# Altium schematic sheet Sensors.SchDoc(Sensors)
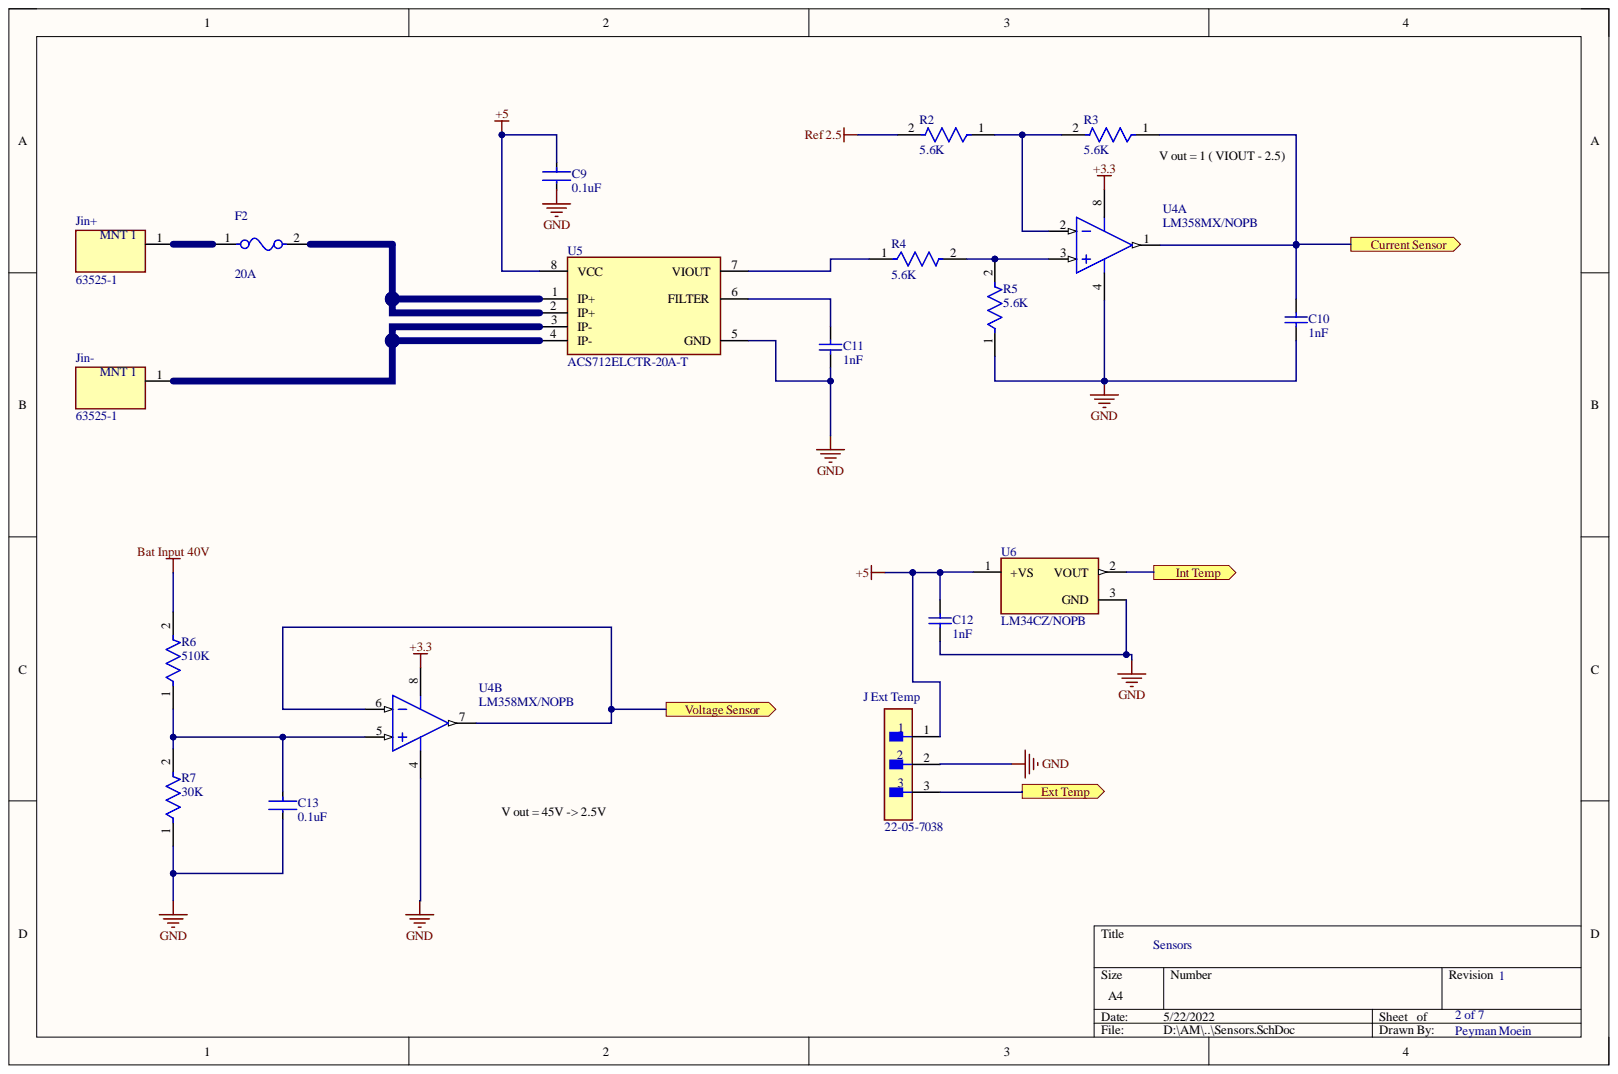
[diagram p0: Sensors.SchDoc]

## Parts
C9  0.1uF  [FP-C0805C-DN-MFG]
C10  1nF  [CAPC2013X70X45NL10T25]
C11  1nF  [CAPC2013X70X45NL10T25]
C12  1nF  [CAPC2013X70X45NL10T25]
C13  0.1uF  [FP-C0805C-DN-MFG]
F2  20A  [FP-0456-MFG]
J_Ext_Temp  22-05-7038  [FP-22-05-7038-MFG]
Jin+  63525-1  [FP-63525-1-MFG]
Jin-  63525-1  [FP-63525-1-MFG]
R2  5.6K  [FP-CRCW0805-e3-MFG]
R3  5.6K  [FP-CRCW0805-e3-MFG]
R4  5.6K  [FP-CRCW0805-e3-MFG]
R5  5.6K  [FP-CRCW0805-e3-MFG]
R6  510K  [FP-CRCW0805-e3-MFG]
R7  30K  [FP-CRCW0805-e3-MFG]
U4  LM358MX/NOPB  [D0008A_L]  (parts A,B)
U5  ACS712ELCTR-20A-T  [FP-LC-8-IPC_C]
U6  LM34CZ/NOPB  [FP-LP0003A-MFG]

## Ports
Current Sensor, Ext Temp, Int Temp, Voltage Sensor

## Nets
+3.3: U4.8
+5: C9.1 C12.2 J_Ext_Temp.1 U5.8(VCC) U6.1
Bat Input 40V: R6.2
GND: C9.2 C10.1 C11.1 C12.1 C13.2 J_Ext_Temp.2 R5.1 R7.1 U4.4 U5.5(GND) U6.3
Ref 2.5: R2.2
Current Sensor: C10.2 R3.1 U4.1 ; port Current Sensor
Ext Temp: J_Ext_Temp.3 ; port Ext Temp
Int Temp: U6.2 ; port Int Temp
Voltage Sensor: U4.6 U4.7 ; port Voltage Sensor
NetC11_2: C11.2 U5.6(FILTER)
NetC13_1: C13.1 R6.1 R7.2 U4.5
NetF2_1: F2.1 Jin+.1
NetF2_2: F2.2 U5.1(IP+) U5.2(IP+)
NetJin-_1: Jin-.1 U5.3(IP-) U5.4(IP-)
NetR2_1: R2.1 R3.2 U4.2
NetR4_1: R4.1 U5.7(VIOUT)
NetR4_2: R4.2 R5.2 U4.3

## Library data of the parts
C9 C13 = CMP-2007-00279-2: CAP CER 0.1UF 50V X7R 0805; Manufacturer: KEMET; Manufacturer Part Number: C0805C104K5RACTU; Capacitance: 0.10 μF; Material: Ceramic; Temperature Coefficient: 30ppm/°C; Tolerance: 0.1; Value: 0.10 μF; Automotive: No; Category: Cap; Component Kind: Standard; ComponentLink2Description: Datasheet; ComponentLink2URL: https://content.kemet.com/datasheets/KEM_C1003_C0G_SMD.pdf; Device Class L1: Passive Components; Device Class L2: Capacitors; Device Class L3: Ceramic Capacitors; Digikey Description: CAP CER 0.1UF 50V X7R 0805; Height: 0.88mm; Ipc Land Pattern Name: CAPC200125X78; Lead Free: Yes; Library Name: Altium Content Vault; Mouser Description: Cap Ceramic 0.1uF 50V X7R 10% SMD 2.0 x 1.2 mm 125 C Paper T/R; Octopart: https://octopart.com/c0805c104k5ractu-kemet-143926; Package: C0805C-DN; RoHS: TRUE; Temperature: -55°C to +125°C; Temperature Characteristic: X7R; Temperature Range High: +125°C; Temperature Range Low: -55°C; Voltage Rating: 50 V
C10-C12 = CMP-2007-00866-1: Manufacturer: Murata; Part Number: GRM2165C1H102JA01D; Component Kind: Standard; Library Name: Altium Content Vault
F2 = CMP-08605-000068-1: FUSE BOARD MNT 20A 125VAC 100VDC; Manufacturer: Littelfuse Inc; Manufacturer Part Number: 0456020.ER; Fuse Blow Speed: Fast; Fuse I2T: 18A2Sec; Fuse Interrupt Ac Current: 300A; Fuse Interrupt Dc Current: 1000A; Fuse Voltage Drop: 64.7mV; Max Fuse Ac Voltage: 125V; Max Fuse Dc Voltage: 100V; Resistance: 2.3mΩ; Value: 2.3mΩ; Automotive: No; Category: Fuse; Component Kind: Standard; ComponentLink2Description: Datasheet; ComponentLink2URL: https://www.littelfuse.com/~/media/electronics/datasheets/fuses/littelfuse_fuse_456_datasheet.pdf.pdf; Device Class L1: Circuit Protection; Device Class L2: Fuses; Device Class L3: unset; Digikey Description: FUSE BOARD MNT 20A 125VAC 100VDC; Fuse Current: 20A; Height: 3.05mm; Lead Free: Yes; Library Name: Altium Content Vault; Mouser Description: Surface Mount Fuses 125V 20A V/FA NANO2; Octopart: https://octopart.com/0456020.er-littelfuse-7502596; Package: 0456; RoHS: TRUE; Temperature: -55°C to +125°C; Temperature Range High: +125°C; Temperature Range Low: -55°C; Voltage Rating: 125V
J_Ext_Temp = CMP-04776-000076-1: CONN HEADER R/A 3POS 2.54MM; Manufacturer: Molex; Conn Gender: Male; Conn Orientation: Right Angle; Current Rating: 4A; Number Of Contacts: 3; Voltage Rating: 500V; Automotive: No; Category: Conn; Component Kind: Standard; ComponentLink2Description: Datasheet; ComponentLink2URL: https://www.molex.com/webdocs/datasheets/pdf/en-us/0022057038_PCB_HEADERS.pdf; Contact Material: Tin,Nickel; Device Class L1: Connectors; Device Class L2: Headers and Wire Housings; Device Class L3: unset; Digikey Description: CONN HEADER R/A 3POS 2.54MM; Footprint Url: https://www.molex.com/pdm_docs/sd/022057038_sd.pdf; Height: 6.05mm; Lead Free: Yes; Library Name: Altium Content Vault; Manufacturer Part Number: 22-05-7038; Mouser Description: 2.54mm (.100") Pitch KK® Wire-to-Board Header, Right Angle, with Friction Lock, 3 Circuits; Number Of Rows: 1; Octopart: https://octopart.com/22-05-7038-molex-773563; Package: 0; Pitch: 2.54mm; RoHS: TRUE; Temperature: -40°C to +105°C; Temperature Range High: +105°C; Temperature Range Low: -40°C
Jin+ Jin- = CMP-03355-000019-1: CONN QC TAB 0.187 SOLDER; Body Material: Brass; Body Plating: Copper; Gender: Male; Hole Diameter: 0.055inch; Insulated Wire Support: Yes; Insulation: Non-Insulated; Mating Tab Width: 4.75mm; PCB Thickness: 0.125inch; Plating: Tin; Tab Thickness: 0.032inch; Tab Width: 0.187inch; Wire Gauge (Max): 16AWG; Wire Gauge (Min): 20AWG; Component Kind: Standard; Contact Material: Brass; Contact Plating: Tin; Depth: 7.11mm; ELV: Compliant; Lead Free: Lead Free; Length: 9.19mm; Library Name: Altium Content Vault; Material: Brass; Orientation: Straight; Package Quantity: 10000; Packaging: Tape and Reel; Radiation Hardening: No; RoHS Compliant: Yes; Series: 187 FASTON; Terminal Material: Brass; Termination: Tab
R2-R7 = CMP-02407-004193-1: RES Thick Film, 2.94Ω, 1%, 0.125W, 100ppm/°C, 0805; Max Power Dissipation: 125mW; Resistance: 2.94R; Voltage Rating: 150V; Case Code (Imperial): 0805; Case Code (Metric): 2012; Case/Package: 0805; Component Kind: Standard; Composition: Thick Film; Contact Plating: Tin; Depth: 1.25mm; Features: Automotive AEC-Q200; Height: 0.02inch; Lead Free: Lead Free; Length: 2mm; Library Name: Altium Content Vault; Max Operating Temperature: 155°C; Military Standard: Not; Min Operating Temperature: -55°C; Number of Terminations: 2; Package Quantity: 10; Packaging: Tape and Reel; Power Rating: 125mW; Radiation Hardening: No; Temperature Coefficient: 100ppm/°C; Termination: SMD/SMT; Tolerance: 1%; Weight: 0.000194oz; Width: 0.049inch
U4 = CMP-2000-07568-1: Low-Power, Dual-Operational Amplifier, 3 to 32 V, 0 to 70 degC, 8-Pin SOIC (D), Green (RoHS & no Sb/Br), Tape and Reel; Manufacturer: Texas Instruments; Bandwidth: 1MHz; Common Mode Rejection Ratio: 65dB; Input Bias Current: 250nA; Input Offset Voltage (Vos): 7mV; Max Dual Supply Voltage: 16V; Max Supply Voltage: 32V; Min Dual Supply Voltage: 1.5V; Min Supply Voltage: 3V; Nominal Supply Current: 500uA; Number of Amplifiers: 2; Output Current: 30mA; Power Dissipation: 530mW; Slew Rate: 0.1V\/us; Voltage Gain: 100dB; Case\/Package: SOIC; Component Kind: Standard; Datasheet URL: http://www.ti.com/lit/ds/symlink/lm358-n.pdf; Datasheet Version: Rev. I, 12/2014; Gain Bandwidth Product: 1MHz; Lead Free: LeadFree; Library Name: Altium Content Vault; Manufacturer URL: http://www.ti.com/; Max Operating Temperature: 70degC; Min Operating Temperature: 0degC; Mount: SurfaceMount; Mounting Technology: SurfaceMount; Number of Channels: 2; Number of Circuits: 2; Number of Elements: 2; Number of Pins: 8; Operating Supply Voltage: 16V; Package Description: 8-Pin Small Outline Integrated Circuit, Body 4.9 x 3.9 mm, Pitch 1.27 mm; Package Quantity: 2500; Package Reference: D0008A; Package URL: http://www.ti.com/lit/ml/msoi002j/msoi002j.pdf; Packaging: TapeandReel; Power Supply Rejection Ratio (PSRR): 65dB; Radiation Hardening: No; REACH SVHC: NoSVHC; RoHSCompliant: True; Unity Gain Bandwidth Product: 1MHz
U5 = CMP-0826-00001-2: SENSOR CURRENT HALL 20A AC/DC; Accuracy: 1.5%; Bandwidth: 80kHz; Max Supply Current: 13mA; Max Supply Voltage: 5.5V; Min Supply Voltage: 4.5V; Number of Channels: 1; Operating Supply Voltage: 5V; Output Current: 10mA; Polarity: Bidirectional; Response Time: 5us; Sensitivity: 100mV/A; Case/Package: SOIC; Component Kind: Standard; Current Sensing: 20A; Frequency: 80kHz; Height: 1.5mm; Lead Free: Lead Free; Length: 4.9mm; Library Name: Altium Content Vault; Linearity: 1.5%; Max Operating Temperature: 85°C; Min Operating Temperature: -40°C; Number of Pins: 8; Package Quantity: 1; Packaging: Tape and Reel; Quiescent Current: 10mA; Radiation Hardening: No; REACH SVHC: No SVHC; RoHS Compliant: Yes; Series: -; Termination: SMD/SMT; Width: 3.9mm
U6 = CMP-04948-000010-1: SENSOR ANALOG -40F-230F TO92-3; Manufacturer: Texas Instruments; Max Supply Voltage: 30V; Min Supply Voltage: 4V; Operating Supply Current: 75-76uA; Output Current: 10mA; Output Voltage: 6V; Sensor Output: Analog Voltage; Ambient Temperature Range High: +230°F; Ambient Temperature Range Low: -40°F; Automotive: No; Category: IC; Component Kind: Standard; ComponentLink2Description: Datasheet; ComponentLink2URL: https://www.ti.com/lit/ds/symlink/lm34.pdf; Device Class L1: Sensors; Device Class L2: Temperature and Humidity Sensors; Device Class L3: unset; Digikey Description: SENSOR ANALOG -40F-230F TO92-3; Lead Free: Yes; Library Name: Altium Content Vault; Manufacturer Part Number: LM34CZ/NOPB; Mouser Description: Board Mount Temperature Sensors PREC FAHRENHEIT TEMP SENSOR; Octopart: https://octopart.com/lm34cz%2Fnopb-texas+instruments-24813949; Package: LP0003A; RoHS: TRUE; Temperature: -40°C to +110°C; Temperature Range High: +110°C; Temperature Range Low: -40°C
